# Altium schematic sheet 02.Power.SchDoc
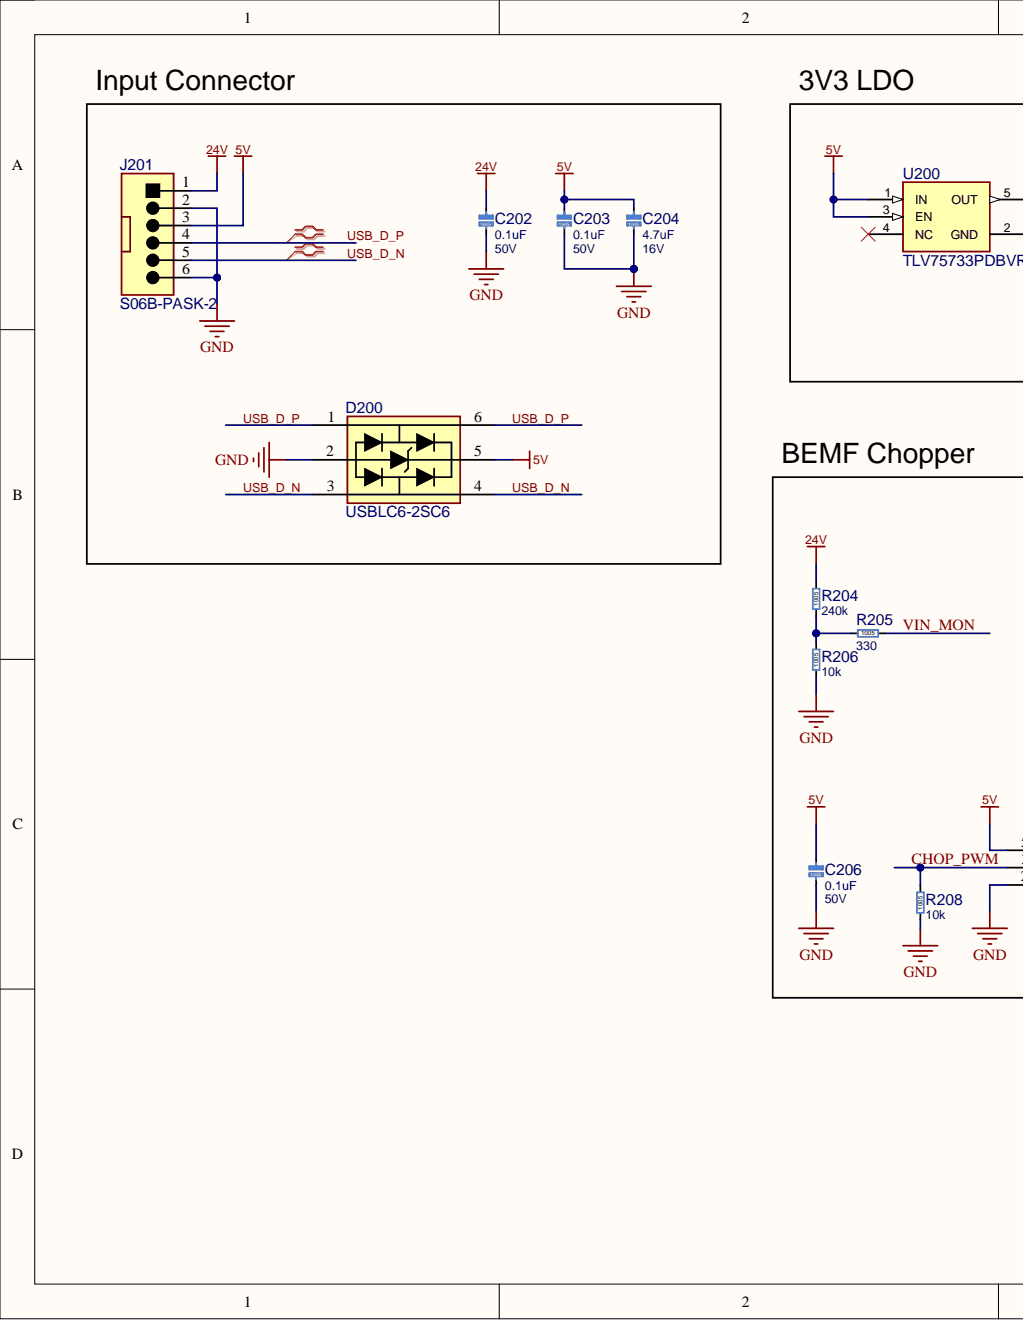
[diagram p0: 02.Power.SchDoc, left]
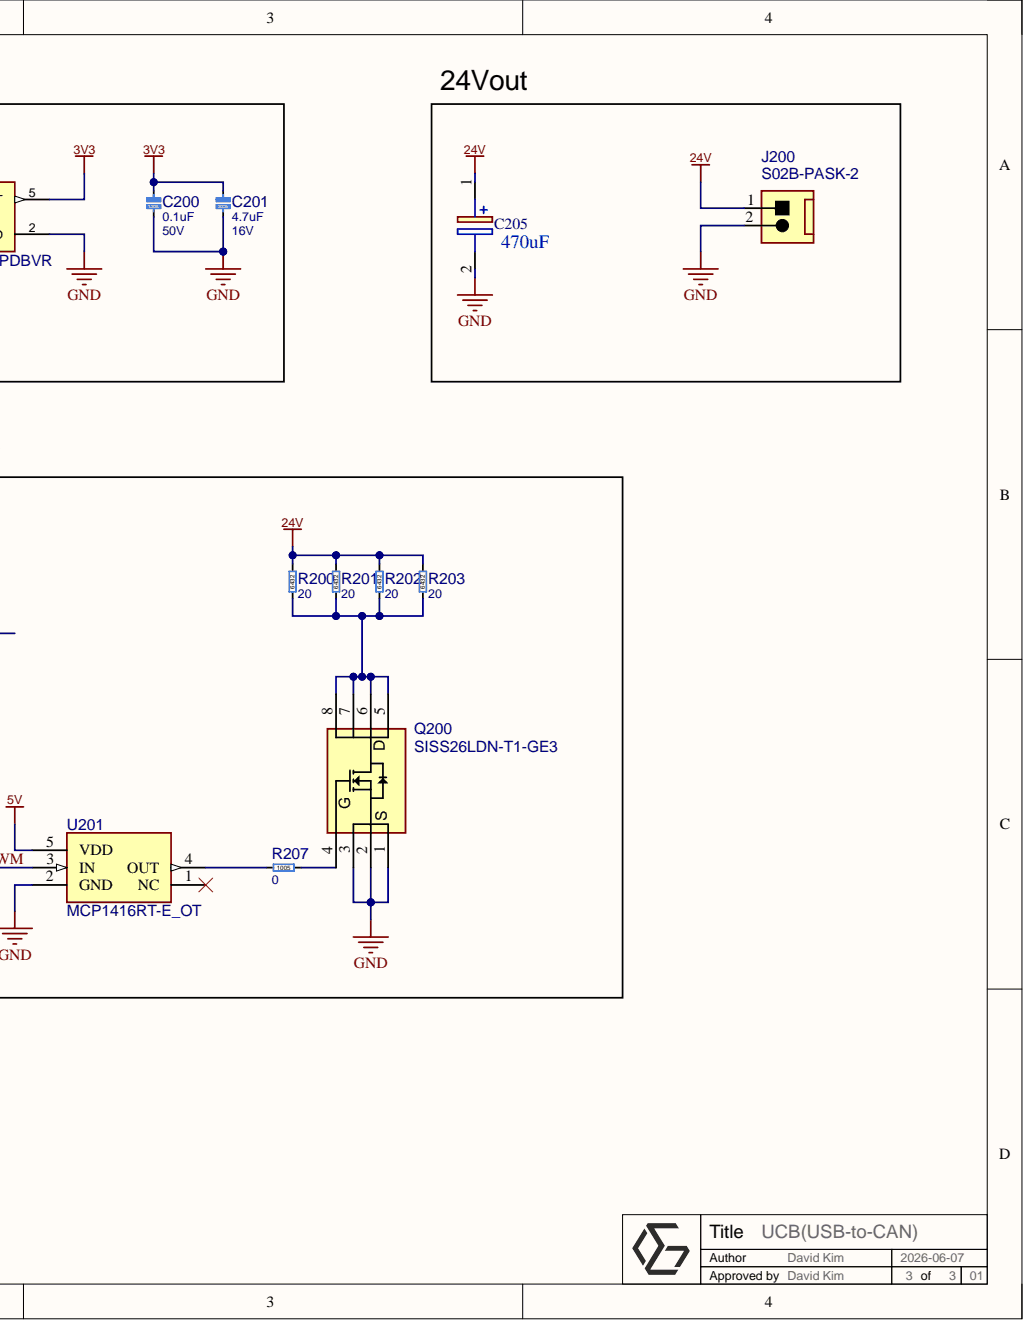
[diagram p1: 02.Power.SchDoc, right]

## Parts
C200  0.1uF  Voltage Rating: 50V  [C-1005]
C201  4.7uF  Voltage Rating: 16V  [C-1005]
C202  0.1uF  Voltage Rating: 50V  [C-1005]
C203  0.1uF  Voltage Rating: 50V  [C-1005]
C204  4.7uF  Voltage Rating: 16V  [C-1005]
C205  470uF  [CAPAE830X1050N]
C206  0.1uF  Voltage Rating: 50V  [C-1005]
D200  USBLC6-2SC6  [SOT95P280X145-6N]
J200  S02B-PASK-2  [S02BPASK2LFSN]
J201  S06B-PASK-2  [S06BPASK2LFSN]
Q200  SISS26LDN-T1-GE3  [SISS26LDNT1GE3]
R200  20  [R-6432]
R201  20  [R-6432]
R202  20  [R-6432]
R203  20  [R-6432]
R204  240k  [R-1005]
R205  330  [R-1005]
R206  10k  [R-1005]
R207  0  [R-1005]
R208  10k  [R-1005]
U200  TLV75733PDBVR  [TI-SOT-23-5]
U201  MCP1416RT-E_OT  [SOT95P270X145-5N]

## Nets
3V3: C200.1 C201.1 U200.5(OUT)
5V: C203.1 C204.1 C206.1 D200.5 J201.3 U200.1(IN) U200.3(EN) U201.5(VDD)
24V: C202.1 C205.1 J200.1 J201.1 R200.2 R201.2 R202.2 R203.2 R204.2
GND: C200.2 C201.2 C202.2 C203.2 C204.2 C205.2 C206.2 D200.2 J200.2 J201.2 J201.6 Q200.1(S) Q200.2 Q200.3 R206.1 R208.1 U200.2(GND) U201.2(GND)
CHOP_PWM: R208.2 U201.3(IN)
USB_D_N: D200.3 D200.4 J201.5
USB_D_P: D200.1 D200.6 J201.4
VIN_MON: R205.2
NetQ200_4: Q200.4 R207.2
NetQ200_5: Q200.5 Q200.6 Q200.7 Q200.8 R200.1 R201.1 R202.1 R203.1
NetR204_1: R204.1 R205.1 R206.2
NetR207_1: R207.1 U201.4(OUT)

Not connected: U200 4(NC); U201 1(NC)

## Library data of the parts
C200-C204 C206 = C-CAP1005: 1005 Size Ceramic MLCC CAP; Tolerance: 10%; Component Kind: Standard; Library Name: UCB_Rev_01.SchLib
  C200 C202 C203 C206: Value: 0.1uF
  C201 C204: Value: 4.7uF
C205 = UCV1V471MNL1GS: Capacitor Polarised; Manufacturer_Name: Nichicon; Arrow Part Number: UCV1V471MNL1GS; Arrow Price/Stock: https://www.arrow.com/en/products/ucv1v471mnl1gs/nichicon; Component Kind: Standard; Datasheet Link: http://www.nichicon.co.jp/english/products/pdfs/e-ucv.pdf; Height: 10.5mm; Manufacturer_Part_Number: UCV1V471MNL1GS; Mouser Part Number: 647-UCV1V471MNL1GS; Mouser Price/Stock: https://www.mouser.co.uk/ProductDetail/Nichicon/UCV1V471MNL1GS?qs=3H4r6eqUTPdWn7aMIduCHQ%3D%3D; Library Name: UCB_Rev_01.SchLib
D200 = D-USBLC6-2SC6: TVS Diode Array Uni-Directional USBLC6-2SC6 17V; Manufacturer_Name: STMicroelectronics; Arrow Part Number: USBLC6-2SC6; Arrow Price/Stock: https://www.arrow.com/en/products/usblc6-2sc6/stmicroelectronics.html?utm_currency=USD&region=nac; Component Kind: Standard; ComponentLink1Description: Datasheet; ComponentLink1URL: https://www.st.com/resource/en/datasheet/usblc6-2.pdf; Datasheet Link: https://www.st.com/resource/en/datasheet/usblc6-2.pdf; Height: 1.45mm; Manufacturer_Part_Number: USBLC6-2SC6; Mouser Part Number: 511-USBLC6-2SC6; Mouser Price/Stock: https://www.mouser.co.uk/ProductDetail/STMicroelectronics/USBLC6-2SC6?qs=po45yt2pPpu%2FhNIlwQdTlg%3D%3D; Library Name: UCB_Rev_01.SchLib
J200 = J-JST-S02B-PASK-2: CONN HEADER RIGHT-ANGLE 6POS 2MM; Manufacturer_Name: JST (JAPAN SOLDERLESS TERMINALS); Manufacturer_Part_Number: S02B-PASK-2 (LF) (SN); Component Kind: Standard; Datasheet Link: http://uk.rs-online.com/web/p/products/5128462; Height: 6.5mm; Library Name: UCB_Rev_01.SchLib
J201 = J-JST-S06B-PASK-2: CONN HEADER RIGHT-ANGLE 6POS 2MM; Manufacturer_Name: JST (JAPAN SOLDERLESS TERMINALS); Manufacturer_Part_Number: S06B-PASK-2(LF)(SN); Arrow Part Number: S06B-PASK-2(LF)(SN); Arrow Price/Stock: https://www.arrow.com/en/products/s06b-pask-2-lf-sn/jst-manufacturing?utm_currency=USD&region=europe; Component Kind: Standard; Datasheet Link: https://www.mouser.de/datasheet/2/564/ePA_F-3476797.pdf; Height: 8.5mm; Mouser Part Number: 306-S06BPASK2LFSN; Mouser Price/Stock: https://www.mouser.co.uk/ProductDetail/JST-Commercial/S06B-PASK-2LFSN?qs=QpmGXVUTftGUdN%2FcuXdcgA%3D%3D; Library Name: UCB_Rev_01.SchLib
Q200 = Q-SISS26LDN-T1-GE3: Trans MOSFET N-CH 60V 23.7A 8-Pin PowerPAK 1212 EP T/R; Manufacturer_Name: Vishay; Component Kind: Standard; ComponentLink1Description: Datasheet; ComponentLink1URL: https://www.vishay.com/doc?76797; Datasheet Link: https://www.vishay.com/doc?76797; Height: 0.83mm; Manufacturer_Part_Number: SISS26LDN-T1-GE3; Mouser Part Number: 78-SISS26LDN-T1-GE3; Mouser Price/Stock: https://www.mouser.co.uk/ProductDetail/Vishay-Siliconix/SISS26LDN-T1-GE3?qs=l4Gc20tDgJIxpPQN7eKKSw%3D%3D; Library Name: UCB_Rev_01.SchLib
R200-R203 = R-RES6432: 6432 Size Chip FIlm Resistor; Power Rating: 3.5W; Tolerance: 1%; Voltage Rating: V; Component Kind: Standard; Value: 20; Library Name: UCB_Rev_01.SchLib
R204-R208 = R-RES1005: 1005 Size Chip FIlm Resistor; Power Rating: W; Tolerance: %; Voltage Rating: V; Component Kind: Standard; Library Name: UCB_Rev_01.SchLib
  R204: Value: 240k
  R205: Value: 330
  R206 R208: Value: 10k
  R207: Value: 0
U200 = U-TI-TLV75733PDBVR: IC REG LINEAR 3.3V 1A SOT23-5; Manufacturer: Texas Instruments; Accuracy Percentage: 1%; Dropout Voltage: 1350mV; Max Junction Temp: +125°C; Max Supply Voltage: 5.5V; Min Supply Voltage: 1.45V; Number Of Outputs: 1; Output Type: Fixed; Standoff Height: 0mm; Automotive: No; Category: IC; Component Kind: Standard; ComponentLink2Description: Datasheet; ComponentLink2URL: http://www.ti.com/lit/ds/symlink/tlv757p.pdf; Device Class L1: Integrated Circuits (ICs); Device Class L2: Power Management ICs; Device Class L3: Voltage Regulators - Linear; Digikey Description: IC REG LINEAR 3.3V 1A SOT23-5; Height: 1.45mm; Ipc Land Pattern Name: SOT95P280X145-5; Lead Free: Yes; Manufacturer Part Number: TLV75733PDBVR; Mouser Description: 1-A low-IQ small-size low-dropout (LDO) regulator 5-SOT-23 -40 to 125; Octopart: https://octopart.com/tlv75733pdbvr-texas+instruments-91437073; Output Current: 1A; Output Voltage: 3.3V; Package: DBV0005A; RoHS: TRUE; Temperature: -40°C to +125°C; Temperature Range High: +125°C; Temperature Range Low: -40°C; Library Name: UCB_Rev_01.SchLib
U201 = U-MCP1416RT-E_OT: Low-Side Gate Driver IC Non-Inverting SOT-23-5; Manufacturer_Name: Microchip; Manufacturer_Part_Number: MCP1416RT-E/OT; Arrow Part Number: MCP1416RT-E/OT; Arrow Price/Stock: https://www.arrow.com/en/products/mcp1416rt-eot/microchip-technology?region=nac; Component Kind: Standard; ComponentLink1Description: Datasheet; ComponentLink1URL: http://www.microchip.com/mymicrochip/filehandler.aspx?ddocname=en536128; Datasheet Link: http://www.microchip.com/mymicrochip/filehandler.aspx?ddocname=en536128; Height: 1.45mm; Mouser Part Number: 579-MCP1416RT-E/OT; Mouser Price/Stock: https://www.mouser.co.uk/ProductDetail/Microchip-Technology/MCP1416RT-E-OT?qs=lG9cgKxQx0lEt8nUXRPbVQ%3D%3D; Library Name: UCB_Rev_01.SchLib
